# Altium schematic sheet FibreReceiver_3c_Output1.SchDoc
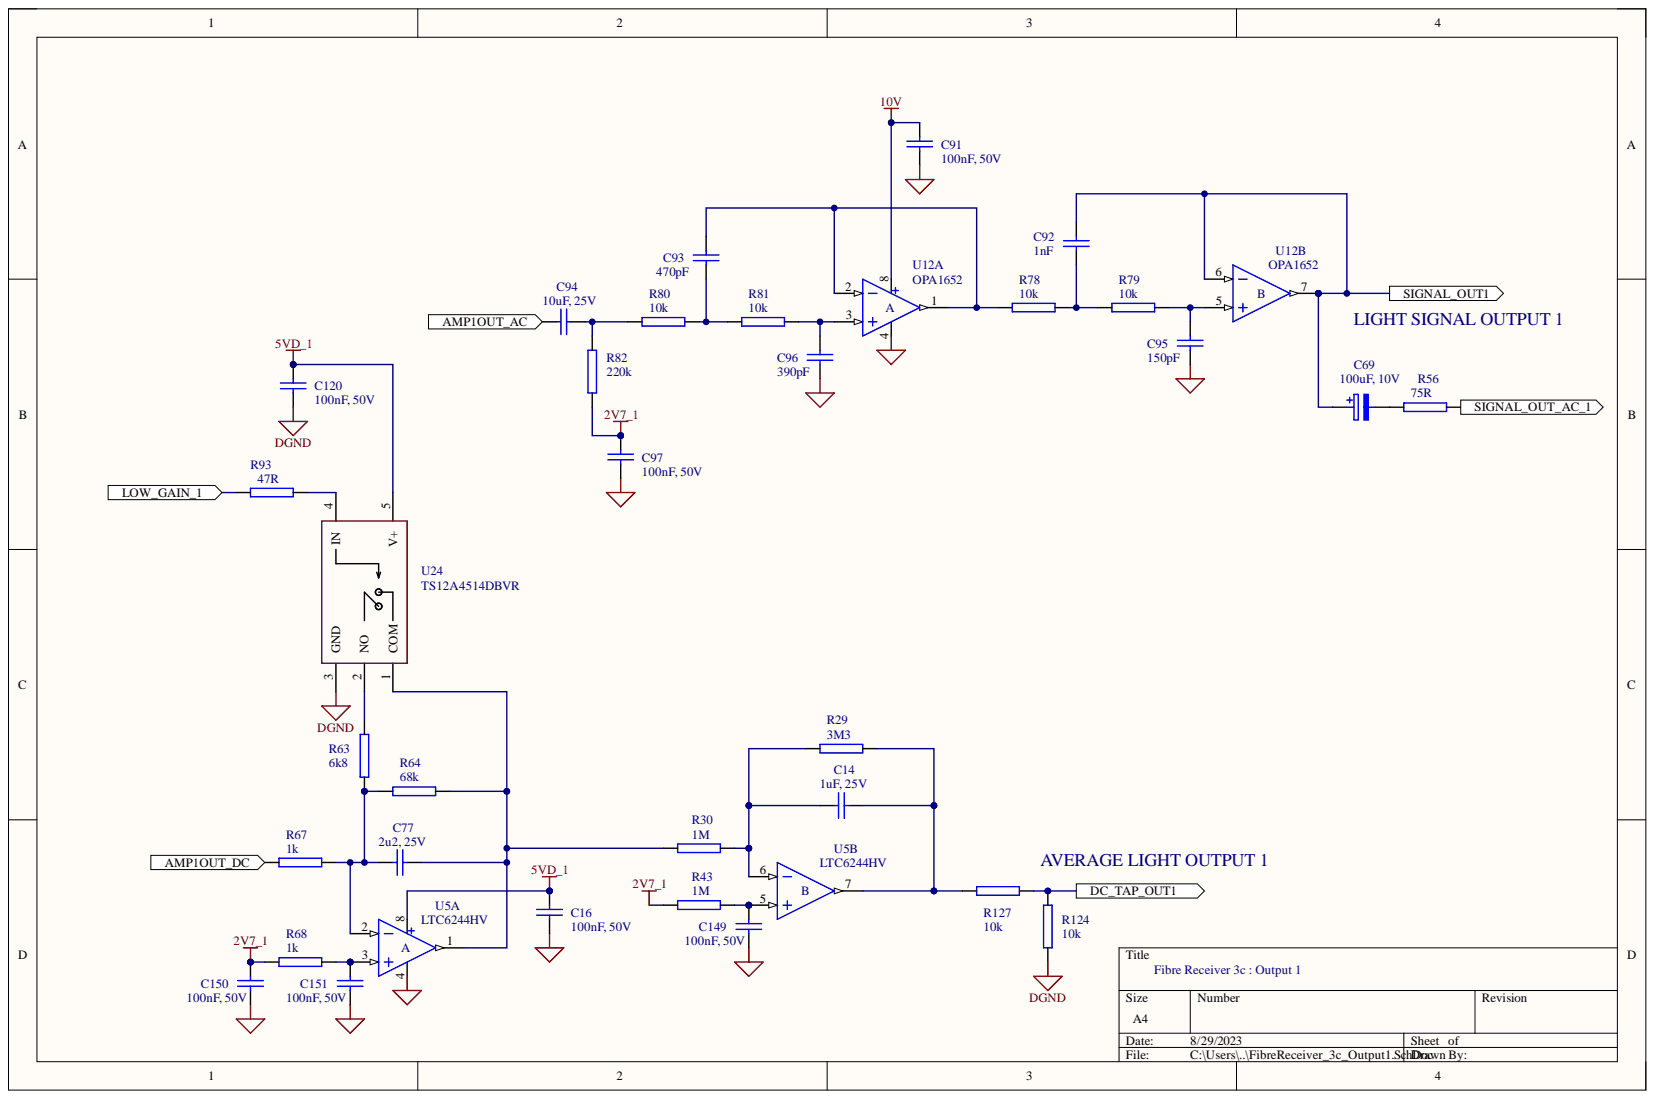
[diagram p0: FibreReceiver_3c_Output1.SchDoc]

## Parts
C14  1uF, 25V  [CAP1206]
C16  100nF, 50V  [CAP0603]
C69  100uF, 10V  [CAP2312]
C77  2u2, 25V  [CAP1206]
C91  100nF, 50V  [CAP0603]
C92  1nF  [CAP0603]
C93  470pF  [CAP0603]
C94  10uF, 25V  [CAP1206]
C95  150pF  [CAP0603]
C96  390pF  [CAP0603]
C97  100nF, 50V  [CAP0603]
C120  100nF, 50V  [CAP0603]
C149  100nF, 50V  [CAP0603]
C150  100nF, 50V  [CAP0603]
C151  100nF, 50V  [CAP0603]
R29  3M3  [RES0603]
R30  1M  [RES0603]
R43  1M  [RES0603]
R56  75R  [RES0603]
R63  6k8  [RES0603]
R64  68k  [RES0603]
R67  1k  [RES0603]
R68  1k  [RES0603]
R78  10k  [RES0603]
R79  10k  [RES0603]
R80  10k  [RES0603]
R81  10k  [RES0603]
R82  220k  [RES0603]
R93  47R  [RES0603]
R124  10k  [RES0603]
R127  10k  [RES0603]
U5  LTC6244HV  [MSOP8]  (parts A,B)
U12  OPA1652  [MSOP8]  (parts A,B)
U24  TS12A4514DBVR  [SOT23-5]

## Ports
AMP1OUT_AC, AMP1OUT_DC, DC_TAP_OUT1, LOW_GAIN_1, SIGNAL_OUT1, SIGNAL_OUT_AC_1

## Nets
2V7_1: C97.1 C150.1 R43.1 R68.1 R82.2
5VD_1: C16.1 C120.1 U5.8 U24.5(V+)
10V: C91.1 U12.8
DGND: C120.2 R124.1 U24.3(GND)
GND: C16.2 C91.2 C95.2 C96.2 C97.2 C149.2 C150.2 C151.2 U5.4(A) U12.4(A)
AMP1OUT_AC: C94.1 ; port AMP1OUT_AC
AMP1OUT_DC: R67.1 ; port AMP1OUT_DC
DC_TAP_OUT1: R124.2 R127.2 ; port DC_TAP_OUT1
LOW_GAIN_1: R93.1 ; port LOW_GAIN_1
SIGNAL_OUT1: C69.1 C92.1 U12.6 U12.7(B) ; port SIGNAL_OUT1
SIGNAL_OUT_AC_1: R56.2 ; port SIGNAL_OUT_AC_1
NetC14_1: C14.1 R29.1 R30.2 U5.6
NetC14_2: C14.2 R29.2 R127.1 U5.7(B)
NetC69_2: C69.2 R56.1
NetC77_1: C77.1 R63.2 R64.1 R67.2 U5.2
NetC77_2: C77.2 R30.1 R64.2 U5.1 U24.1(COM)
NetC92_2: C92.2 R78.2 R79.1
NetC93_1: C93.1 R78.1 U12.1 U12.2
NetC93_2: C93.2 R80.2 R81.1
NetC94_2: C94.2 R80.1 R82.1
NetC95_1: C95.1 R79.2 U12.5
NetC96_1: C96.1 R81.2 U12.3
NetC149_1: C149.1 R43.2 U5.5
NetC151_1: C151.1 R68.2 U5.3
NetR63_1: R63.1 U24.2(NO)
NetR93_2: R93.2 U24.4(IN)

## Library data of the parts
C14 = CAP SMD 1UF 25V CAP1206: CAP SMD 1206 1uF +/-10% 25V X7R; Manuf: TDK; Manuf Part Num: C3216X7R1E105K085AA; Component Kind: Standard; Supplier 1: element14; Supplier 1 Part Num: 252-2132; Supplier 2: DigiKey; Supplier 2 Part Num: 445-1592-1-ND; Supplier 3: RS; Supplier 3 Part Num: 916-2942; Library Name: BinopiSymbols_mn.SchLIB
C16 C91 C97 C120 C149-C151 = CAP SMD 100NF 50V CAP0603: CAP SMD 0603 100nF +/-10% 50V X7R; Manuf: Kemet; Manuf Part Num: C0603C104K5RACTU; Component Kind: Standard; Supplier 1: element14; Supplier 1 Part Num: 128-8255; Supplier 2: DigiKey; Supplier 2 Part Num: 399-5089-1-ND; Library Name: BinopiSymbols_mn.SchLIB
C69 = CAP TANT 100UF 10V CAP2312: CAP TANT 100uF 10% 10V; Manuf: AVX; Manuf Part Num: TAJC107K010RNJ; Component Kind: Standard; Supplier 1: element14; Supplier 1 Part Num: 197-180; Supplier 2: DigiKey; Supplier 2 Part Num: 478-1704-1-ND; Library Name: BinopiSymbols_mn.SchLIB
C77 = CAP SMD 2.2UF 25V CAP1206: CAP SMD 1206 2.2UF 10% 25V X7R; Component Kind: Standard; Supplier 1: DigiKey; Supplier 1 Part Num: 445-1382-1-ND; Supplier 2: Mouser; Supplier 2 Part Num: 810-C3216X7R1E225K; Supplier 3: element14; Supplier 3 Part Num: 252-2134; Library Name: BinopiSymbols_mn.SchLIB
C92 = CAP SMD 1NF 50V CAP0603 X7R: CAP SMD 0603 1nF +/-10% 50V X7R; Manuf: AVX; Manuf Part Num: 06035C102KAZ2A; Component Kind: Standard; Supplier 1: element14; Supplier 1 Part Num: 756-9513; Supplier 2: DigiKey; Supplier 2 Part Num: 478-3526-1-ND; Library Name: BinopiSymbols_mn.SchLIB
C93 = CAP SMD 470PF 50V CAP0603: CAP SMD 0603 470pF +/-5% 50V COG; Manuf: TDK; Manuf Part Num: CGA3E2C0G1H471J080AA; Component Kind: Standard; Supplier 1: element14; Supplier 1 Part Num: 243-5472; Supplier 2: DigiKey; Supplier 2 Part Num: 445-5648-1-ND; Library Name: BinopiSymbols_mn.SchLIB
C94 = CAP SMD 10UF 25V CAP1206: CAP SMD 1206 10uF +/-10% 25V X5R; Manuf: Murata; Manuf Part Num: GRM319R61E106KA12D; Component Kind: Standard; Supplier 1: Digikey; Supplier 1 Part Num: 490-5525-1-ND; Supplier 2: element14; Supplier 2 Part Num: 184-5759; Library Name: BinopiSymbols_mn.SchLIB
C95 = CAP SMD 150PF 50V CAP0603: CAP SMD 0603 150pF 5% 50V C0G/NP0; Manuf: Yageo; Manuf Part Num: CC0603JRNPO9BN151; Component Kind: Standard; Supplier 1: element14; Supplier 1 Part Num: 722-108; Supplier 2: DigiKey; Supplier 2 Part Num: 311-1071-1-ND; Library Name: BinopiSymbols_mn.SchLIB
C96 = CAP SMD 390PF 50V CAP0603: CAP SMD 0603 390pF 5% 50V C0G/NP0; Manuf: Yageo; Manuf Part Num: CC0603JRNPO9BN391; Component Kind: Standard; Supplier 1: element14; Supplier 1 Part Num: 301-9512; Supplier 2: DigiKey; Supplier 2 Part Num: 311-1076-1-ND; Library Name: BinopiSymbols_mn.SchLIB
R29 = RES 3M3 RES0603: RES SMD 0603 3M3 1%; Manuf: Vishay; Manuf Part Num: CRCW06033M30FKEA; Component Kind: Standard; Supplier 1: Digikey; Supplier 1 Part Num: 541-3.30MHTR-ND; Supplier 2: element14; Supplier 2 Part Num: 213-8666; Library Name: Binopi Symbols.SchLIB
R30 R43 = RES 1M RES0603: RES SMD 0603 1M 1% 0.1W; Manuf: Yageo; Manuf Part Num: RC0603FR-071ML; Component Kind: Standard; Supplier 1: Digi-Key; Supplier Part Number 1: 311-1.00MHRCT-ND; Library Name: Binopi Symbols.SchLIB
R56 = RES 75R RES0603: RES SMD 0603 75R 1% 0.062W; Manuf: Panasonic; Manuf Part Num: ERJ-PA3F75R0V; Component Kind: Standard; Supplier 1: element14; Supplier 1 Part Num: 233-5951; Supplier 2: Digikey; Supplier 2 Part Num: P75.00BYCT-ND; Library Name: BinopiSymbols_mn.SchLIB
R63 = RES 6K8 RES0603: RES SMD 0603 6k8 1% 0.063W; Manuf: Yageo; Manuf Part Num: RC0603FR-076K8L; Component Kind: Standard; Supplier 1: Digikey; Supplier 1 Part Num: 311-6.80KHRTR-ND; Supplier 2: element14; Supplier 2 Part Num: 214-6777; Library Name: BinopiSymbols_mn.SchLIB
R64 = RES 68K RES0603: RES SMD 0603 68K 1% 0.063W; Manuf: Yageo; Manuf Part Num: RC0603FR-0768K; Component Kind: Standard; Supplier 1: Digikey; Supplier 1 Part Num: 311-68.0KHRCT-ND; Supplier 2: element14; Supplier 2 Part Num: 214-7041; Library Name: BinopiSymbols_mn.SchLIB
R67 R68 = RES 1K RES0603: RES SMD 0603 1k 1% 0.1W; Manuf: Yageo; Manuf Part Num: RC0603FR-071KL; Component Kind: Standard; Supplier 1: Digikey; Supplier 1 Part Num: 311-1.00KHRTR-ND; Supplier 2: element14; Supplier 2 Part Num: 911-239; Library Name: BinopiSymbols_mn.SchLIB
R78-R81 R124 R127 = RES 10K RES0603: RES SMD 0603 10K 1% 0.1W; Manuf: Yageo; Manuf Part Num: RC0603FR-0710KL; Component Kind: Standard; Supplier 1: Digikey; Supplier 1 Part Num: 311-10.0KHRTR-ND; Supplier 2: element14; Supplier 2 Part Num: 213-3286; Library Name: BinopiSymbols_mn.SchLIB
R82 = RES 220K RES0603: RES SMD 0603 220k 1% 0.1W; Manuf: Yageo; Manuf Part Num: RC0603FR-07220KL; Component Kind: Standard; Supplier 1: Digi-Key; Supplier Part Number 1: 311-220KHRTR-ND; Library Name: Binopi Symbols.SchLIB
R93 = RES 47R RES0603: RES SMD 0603 47R 1% 0.063W; Manuf: Vishay / Dale; Manuf Part Num: CRCW060347R0FKEA; Component Kind: Standard; Supplier 1: Mouser; Supplier 1 Part Num: 71-CRCW0603-47-E3; Supplier 2: DigiKey; Supplier 2 Part Num: 541-47.0HCT-ND; Library Name: BinopiSymbols_mn.SchLIB
U5 = IC LTC6244HV: IC-OPAMP LTC6244HV LowNse Dual 50MHz; Manuf: Linear Technology; Manuf Part Num: LTC6244HVCMS8#PBF; Component Kind: Standard; Supplier 1: element14; Supplier 1 Part Num: 229-4751; Supplier 2: DigiKey; Supplier 2 Part Num: LTC6244HVCMS8#PBF-ND; Library Name: BinopiSymbols_mn.SchLIB
U12 = IC OPA1652: IC-OPAMP OPA1652 LowNse Dual 18MHz; Manuf: Texas Instruments; Manuf Part Num: OPA1652AIDGKR; Component Kind: Standard; Supplier 1: Mouser; Supplier 1 Part Num: 595-OPA1652AIDGKR; Supplier 2: DigiKey; Supplier 2 Part Num: 296-36688-1-ND; Library Name: BinopiSymbols_mn.SchLIB
U24 = IC TS12A4514DBVR ANALOG SWITCH SOT23-5: TS12A4514DBVR Analog Switch; Manuf: Texas Instruments; Component Kind: Standard; Manuf Part Num: TS12A4514DBVR; Supplier 1: element14; Supplier 1 Part Num: 238-2821; Supplier 2: DigiKey; Supplier 2 Part Num: 296-24201-1-ND; Library Name: BinopiSymbols_mn.SchLIB
